# Altium schematic sheet MEMS MICs.SchDoc("MEMS MICs")
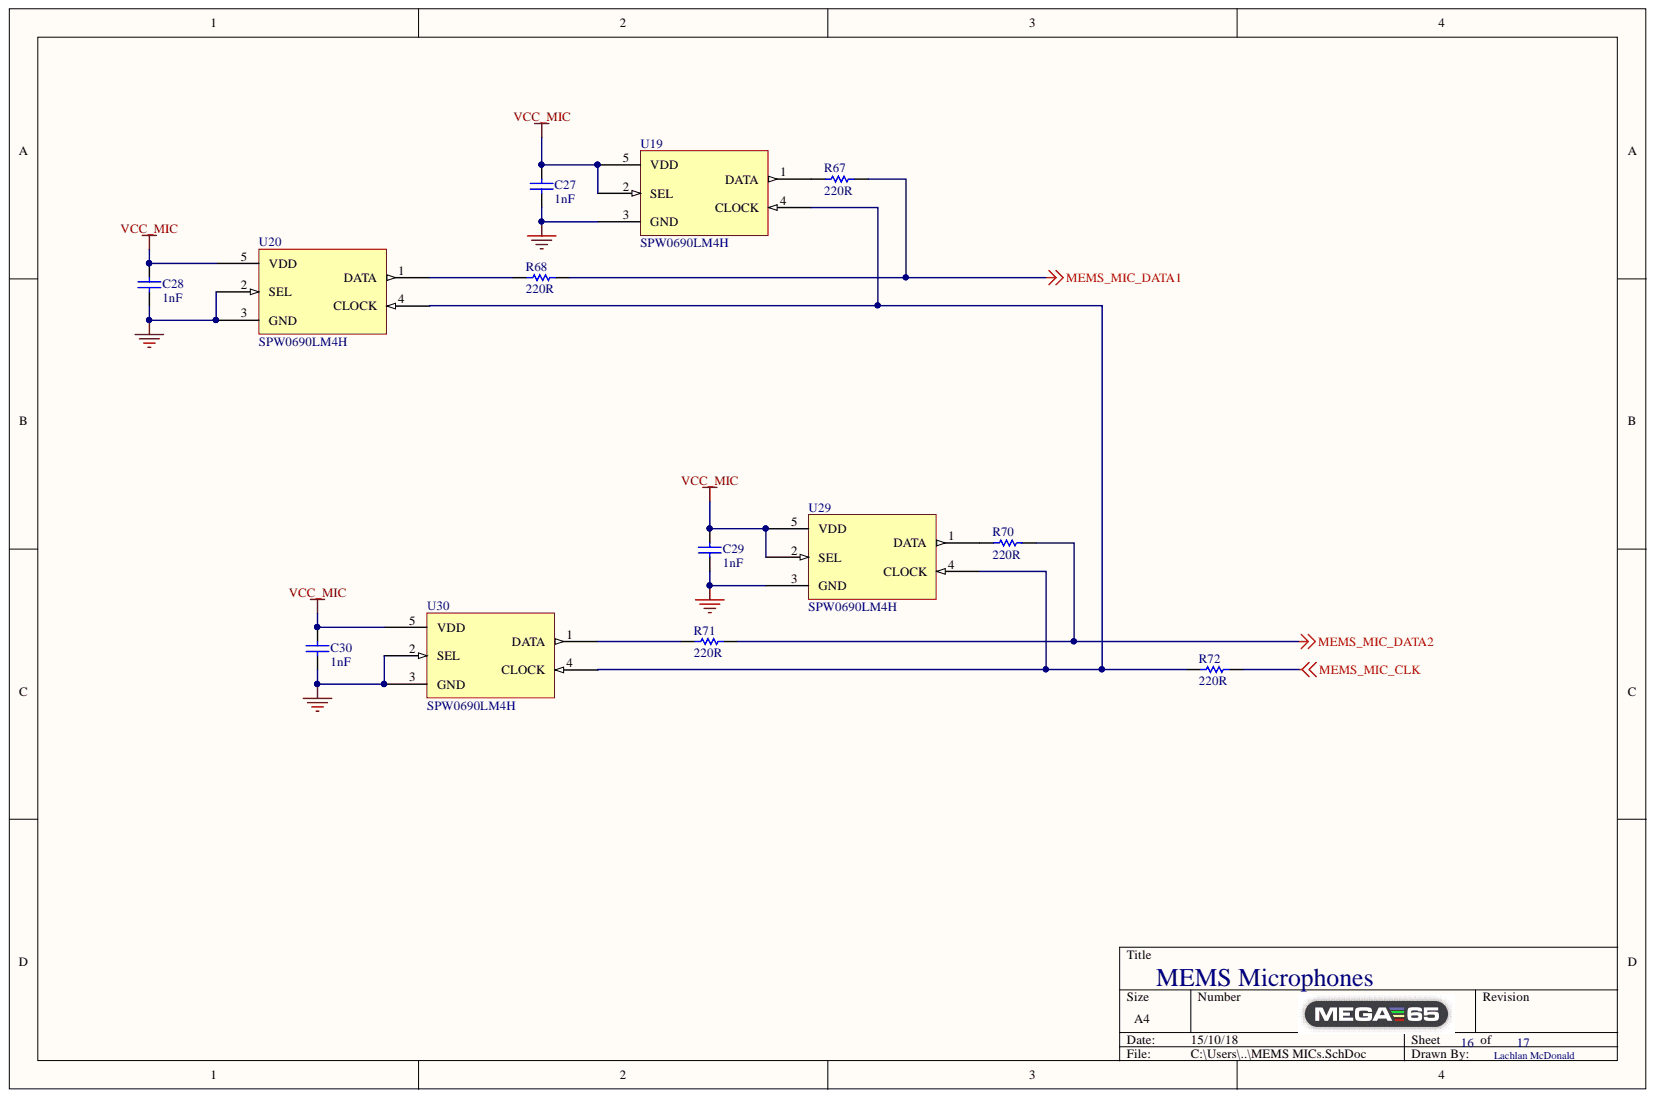
[diagram p0: MEMS MICs.SchDoc]

## Parts
C27  1nF  [CAPC0805(2012)100_N]
C28  1nF  [CAPC0805(2012)100_N]
C29  1nF  [CAPC0805(2012)100_N]
C30  1nF  [CAPC0805(2012)100_N]
R67  220R  [RESC0805(2012)_M]
R68  220R  [RESC0805(2012)_M]
R70  220R  [RESC0805(2012)_M]
R71  220R  [RESC0805(2012)_M]
R72  220R  [RESC0805(2012)_M]
U19  SPW0690LM4H  [SPW0690LM4H-1]
U20  SPW0690LM4H  [SPW0690LM4H-1]
U29  SPW0690LM4H  [SPW0690LM4H-1]
U30  SPW0690LM4H  [SPW0690LM4H-1]

## Nets
GND: C27.2 C28.2 C29.2 C30.2 U19.3(GND) U20.2(SEL) U20.3(GND) U29.3(GND) U30.2(SEL) U30.3(GND)
MEMS_MIC_CLK: R72.1
MEMS_MIC_DATA1: R67.1 R68.1
MEMS_MIC_DATA2: R70.1 R71.1
VCC_MIC: C27.1 C28.1 C29.1 C30.1 U19.2(SEL) U19.5(VDD) U20.5(VDD) U29.2(SEL) U29.5(VDD) U30.5(VDD)
NetR67_2: R67.2 U19.1(DATA)
NetR68_2: R68.2 U20.1(DATA)
NetR70_2: R70.2 U29.1(DATA)
NetR71_2: R71.2 U30.1(DATA)
NetR72_2: R72.2 U19.4(CLOCK) U20.4(CLOCK) U29.4(CLOCK) U30.4(CLOCK)

## Library data of the parts
C27-C30 = CMP-1036-01531-1: CAP 39pF 100V ±2% 0805 (2012 Metric) Thickness 1mm SMD; Tolerance: Â±2%; Case-Metric: 2012; Case-EIA: 0805; Value: 39pF; Technology: SMT; Rated Voltage: 100 V; Max Thickness: 1 mm; Library Name: Altium Content Vault; Component Kind: Standard
R67 R68 R70-R72 = CMP-1013-00623-1: 10K 0.125W 1% 0805 (2012 Metric)  SMD; Value: 10000.00 Ohm; Case-Metric: 2012; Case-EIA: 0805; Tolerance: 1 %; Technology: SMT; Power: 0.125 W; Library Name: Altium Content Vault; Component Kind: Standard
U19 U20 U29 U30 = MEMs Microphone: Library Name: Schlib1.SchLib; Component Kind: Standard
